# Altium schematic sheet RemoteFan.SchDoc
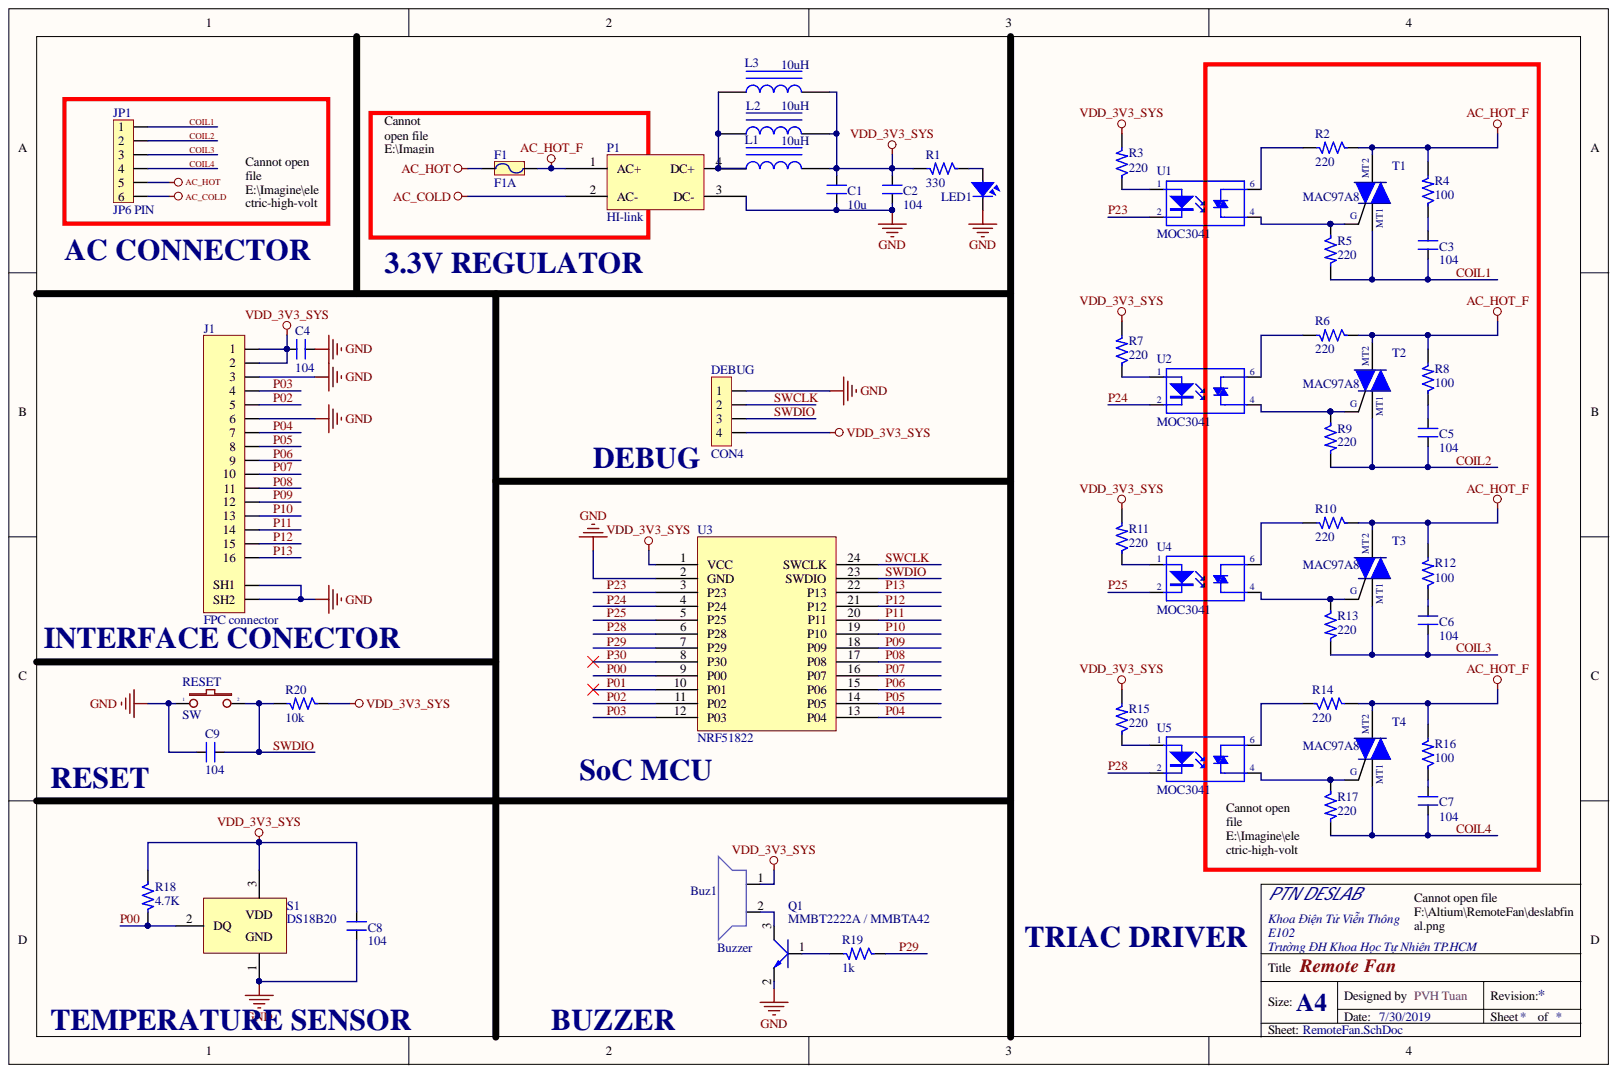
[diagram p0: RemoteFan.SchDoc]

## Parts
Buz1  Buzzer  [BUZZER_300mil]
C1  10u  [0805_Cap]
C2  104  [0603_cap]
C3  104  [CN4]
C4  104  [0603_cap]
C5  104  [CN4]
C6  104  [CN4]
C7  104  [CN4]
C8  104  [0603_cap]
C9  104  [0603_cap]
DEBUG  CON4  [JP4]
F1  F1A  [Fuse]
J1  FPC connector  [FPC 0.5MM 16P TOP]
JP1  JP6 PIN  [6P - TERMINAL BLOCK 9.5MM]
L1  value 10uH  [0805_Inductor]  (hidden comment: Inductor Iron)
L2  value 10uH  [0805_Inductor]  (hidden comment: Inductor Iron)
L3  value 10uH  [0805_Inductor]  (hidden comment: Inductor Iron)
LED1  LED  [LED-3]
P1  HI-link  [Hi-link]
Q1  MMBT2222A / MMBTA42  [SOT23]
R1  330  [0603_res]
R2  220  [0603_res]
R3  220  [0603_res]
R4  100  [STACKPOLE CSRN2512]
R5  220  [0603_res]
R6  220  [0603_res]
R7  220  [0603_res]
R8  100  [STACKPOLE CSRN2512]
R9  220  [0603_res]
R10  220  [0603_res]
R11  220  [0603_res]
R12  100  [STACKPOLE CSRN2512]
R13  220  [0603_res]
R14  220  [0603_res]
R15  220  [0603_res]
R16  100  [STACKPOLE CSRN2512]
R17  220  [0603_res]
R18  4.7K  [0603_res]
R19  1k  [0603_res]
R20  10k  [0603_res]
RESET  SW  [Tact Switch TS6X3.6]
S1  DS18B20  [TO-92]
T1  MAC97A8  [TO-92 - S254]
T2  MAC97A8  [TO-92 - S254]
T3  MAC97A8  [TO-92 - S254]
T4  MAC97A8  [TO-92 - S254]
U1  MOC3041  [DIP6/W0.3]
U2  MOC3041  [DIP6/W0.3]
U3  NRF51822  [NRF51822_M1 hole]
U4  MOC3041  [DIP6/W0.3]
U5  MOC3041  [DIP6/W0.3]

## Nets
AC_COLD: JP1.6 P1.2(AC-)
AC_HOT: F1.1 JP1.5
AC_HOT_F: F1.2 P1.1(AC+) R2.1 R4.2 R6.1 R8.2 R10.1 R12.2 R14.2 R16.2 T1.3 T2.3 T3.3 T4.3
GND: C1.1 C2.1 C4.1 C8.2 C9.1 DEBUG.1 J1.3 J1.6 J1.17(SH1) J1.18(SH2) LED1.2 P1.3(DC-) Q1.2 RESET.1 S1.1 U3.2(GND)
VDD_3V3_SYS: Buz1.1 C1.2 C2.2 C4.2 C8.1 DEBUG.4 J1.1 J1.2 L1.2 L2.2 L3.2 R1.2 R3.2 R7.2 R11.2 R15.2 R18.1 R20.1 S1.3 U3.1(VCC)
COIL1: C3.1 JP1.1 R5.2 T1.1
COIL2: C5.1 JP1.2 R9.2 T2.1
COIL3: C6.1 JP1.3 R13.2 T3.1
COIL4: C7.1 JP1.4 R17.2 T4.1
P00: R18.2 S1.2 U3.9(P00)
P01: U3.10(P01)
P02: J1.5 U3.11(P02)
P03: J1.4 U3.12(P03)
P04: J1.7 U3.13(P04)
P05: J1.8 U3.14(P05)
P06: J1.9 U3.15(P06)
P07: J1.10 U3.16(P07)
P08: J1.11 U3.17(P08)
P09: J1.12 U3.18(P09)
P10: J1.13 U3.19(P10)
P11: J1.14 U3.20(P11)
P12: J1.15 U3.21(P12)
P13: J1.16 U3.22(P13)
P23: U1.2 U3.3(P23)
P24: U2.2 U3.4(P24)
P25: U3.5(P25) U4.2
P28: U3.6(P28) U5.2
P29: R19.1 U3.7(P29)
P30: U3.8(P30)
SWCLK: DEBUG.2 U3.24(SWCLK)
SWDIO: C9.2 DEBUG.3 R20.2 RESET.2 U3.23(SWDIO)
NetBuz1_2: Buz1.2 Q1.3
NetC3_2: C3.2 R4.1
NetC5_2: C5.2 R8.1
NetC6_2: C6.2 R12.1
NetC7_2: C7.2 R16.1
NetL1_1: L1.1 L2.1 L3.1 P1.4(DC+)
NetLED1_1: LED1.1 R1.1
NetQ1_1: Q1.1 R19.2
NetR2_2: R2.2 U1.6
NetR3_1: R3.1 U1.1
NetR5_1: R5.1 T1.2 U1.4
NetR6_2: R6.2 U2.6
NetR7_1: R7.1 U2.1
NetR9_1: R9.1 T2.2 U2.4
NetR10_2: R10.2 U4.6
NetR11_1: R11.1 U4.1
NetR13_1: R13.1 T3.2 U4.4
NetR14_1: R14.1 U5.6
NetR15_1: R15.1 U5.1
NetR17_1: R17.1 T4.2 U5.4

## Library data of the parts
C1 C2 C4 C8 C9 = CM: Cap SMD; Value: 103; Component Kind: Standard; Library Name: MyLib.SchLib
C3 C5-C7 = CN4: Cap np W0.4; Value: 0.47uF; Component Kind: Standard; Library Name: MyLib.SchLib
DEBUG = jp4: Component Kind: Standard; Library Name: MyLib.SchLib
F1 = Fuse: Designed by: VanDungEvn; Component Kind: Standard; Description: Fuse; Library Name: MyLib.SchLib; Value: Fuse
J1 = FPC16: Component Kind: Standard; Library Name: MyLib.SchLib
JP1 = JP6: Value: JP7; Component Kind: Standard; Description: JP6 PIN; Library Name: MyLib.SchLib
L1-L3 = Inductor Iron: Magnetic-Core Inductor; Manufacturer: Generic Components; Class: Passive; Component Kind: Standard; Library Name: MyLib.SchLib; Published: 1999; SubClass: Inductor
LED1 = LED: LED Single colour Green and Red; Value: LED 3 ly Single Colour; Component Kind: Standard; Library Name: MyLib.SchLib
Q1 = npn_MMBT2222A: TRANS NPN 40V 350MW SMD SOT23-3; Component Kind: Standard; Library Name: MyLib.SchLib
R1-R20 = R: Resistor 1/4W; Value: R1/4W - 1K; Component Kind: Standard; Library Name: MyLib.SchLib
RESET = SW2: Switch button 2 pin; Value: SW chip 2 pin; Component Kind: Standard; Library Name: MyLib.SchLib
U1 U2 U4 U5 = MOC3041: Single Opto; Value: MOC3021; Component Kind: Standard; Library Name: MyLib.SchLib
U3 = NRF51822_MI_MODULE: Component Kind: Standard; Library Name: MyLib.SchLib
Buz1 = Buzzer: Component Kind: Standard; Library Name: MyLib.SchLib
P1 = HI-link: Component Kind: Standard; Library Name: MyLib.SchLib
S1 = DS18B20: Component Kind: Standard; Library Name: MyLib.SchLib
T1-T4 = MAC97A8: Component Kind: Standard; Library Name: MyLib.SchLib
